# Altium schematic sheet 5.04.51805A02_RKWIFI_BT.SchDoc(5.04.51805A02_RKWIFI_BT)
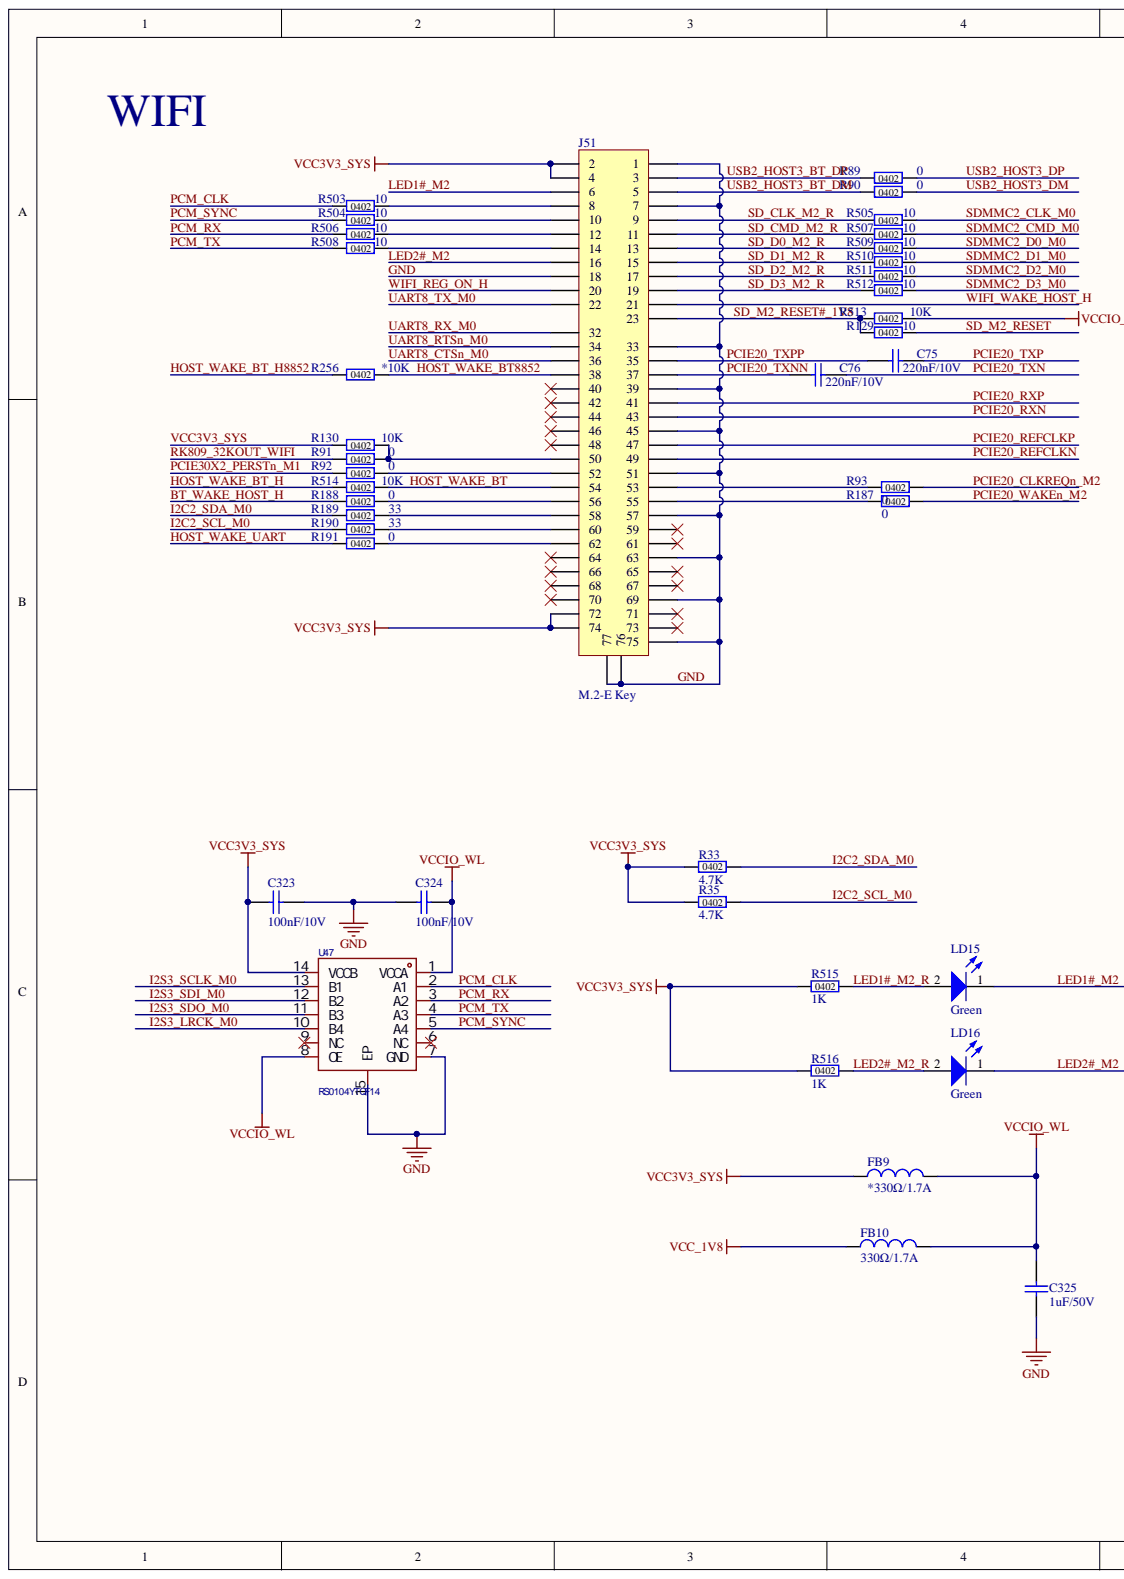
[diagram p0: 5.04.51805A02_RKWIFI_BT.SchDoc, left]
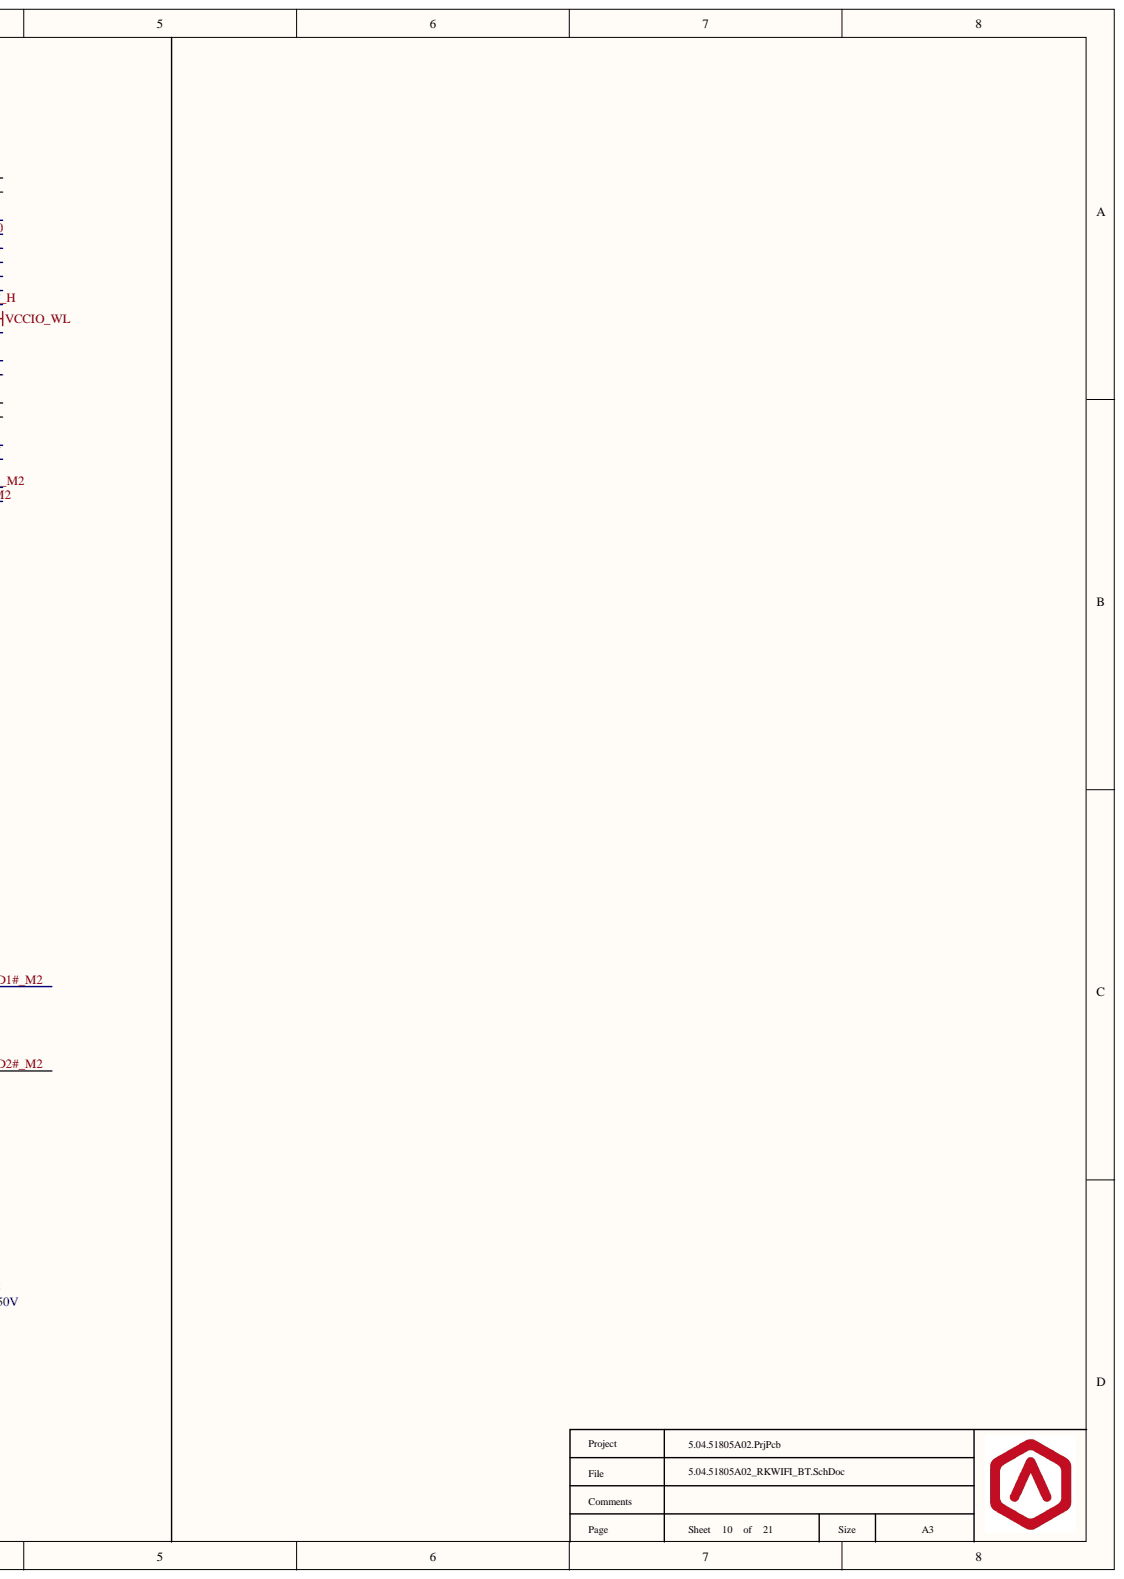
[diagram p1: 5.04.51805A02_RKWIFI_BT.SchDoc, right]

## Parts
C75  220nF/10V  [C0402]
C76  220nF/10V  [C0402]
C323  100nF/10V  [C0402]
C324  100nF/10V  [C0402]
C325  1uF/50V  [C0603]
FB9  *330Ω/1.7A  [L0603]
FB10  330Ω/1.7A  [L0603]
J51  M.2-E Key  [CONN-SMD_APCI0108-P001A]
LD15  Green  [LED0603_2]
LD16  Green  [LED0603_2]
R33  4.7K  [R0402]
R35  4.7K  [R0402]
R89  0  [R0402]
R90  0  [R0402]
R91  0  [R0402]
R92  0  [R0402]
R93  0  [R0402]
R129  10  [R0402]
R130  10K  [R0402]
R187  0  [R0402]
R188  0  [R0402]
R189  33  [R0402]
R190  33  [R0402]
R191  0  [R0402]
R256  *10K  [R0402]
R503  10  [R0402]
R504  10  [R0402]
R505  10  [R0402]
R506  10  [R0402]
R507  10  [R0402]
R508  10  [R0402]
R509  10  [R0402]
R510  10  [R0402]
R511  10  [R0402]
R512  10  [R0402]
R513  10K  [R0402]
R514  10K  [R0402]
R515  1K  [R0402]
R516  1K  [R0402]
U47  RS0104YTQF14  [VQFN-14_L3.5-W3.5-P0.50-BL-EP2.0]

## Nets
VCC_1V8: FB10.1
VCCIO_WL: C324.2 C325.2 FB9.2 FB10.2 R513.2 U47.1(VCCA) U47.8(OE)
BT_WAKE_HOST_H: R188.1
GND: C323.2 C324.1 C325.1 J51.1 J51.7 J51.18 J51.33 J51.39 J51.45 J51.51 J51.57 J51.63 J51.69 J51.75 J51.76 J51.77 U47.7(GND) U47.15(EP)
HOST_WAKE_BT: J51.54 R514.2
HOST_WAKE_BT8852: J51.38 R256.2
HOST_WAKE_BT_H: R514.1
HOST_WAKE_BT_H8852: R256.1
HOST_WAKE_UART: R191.1
I2C2_SCL_M0: R35.2 R190.1
I2C2_SDA_M0: R33.2 R189.1
I2S3_LRCK_M0: U47.10(B4)
I2S3_SCLK_M0: U47.13(B1)
I2S3_SDI_M0: U47.12(B2)
I2S3_SDO_M0: U47.11(B3)
LED1#_M2: J51.6 LD15.1
LED1#_M2_R: LD15.2 R515.2
LED2#_M2: J51.16 LD16.1
LED2#_M2_R: LD16.2 R516.2
PCIE20_CLKREQN_M2: R93.2
PCIE20_REFCLKN: J51.49
PCIE20_REFCLKP: J51.47
PCIE20_RXN: J51.43
PCIE20_RXP: J51.41
PCIE20_TXN: C76.2
PCIE20_TXNN: C76.1 J51.37
PCIE20_TXP: C75.2
PCIE20_TXPP: C75.1 J51.35
PCIE20_WAKEN_M2: R187.2
PCIE30X2_PERSTN_M1: R92.1
PCM_CLK: R503.1 U47.2(A1)
PCM_RX: R506.1 U47.3(A2)
PCM_SYNC: R504.1 U47.5(A4)
PCM_TX: R508.1 U47.4(A3)
RK809_32KOUT_WIFI: R91.1
SD_CLK_M2_R: J51.9 R505.1
SD_CMD_M2_R: J51.11 R507.1
SD_D0_M2_R: J51.13 R509.1
SD_D1_M2_R: J51.15 R510.1
SD_D2_M2_R: J51.17 R511.1
SD_D3_M2_R: J51.19 R512.1
SD_M2_RESET: R129.2
SD_M2_RESET#_1V8: J51.23 R129.1 R513.1
SDMMC2_CLK_M0: R505.2
SDMMC2_CMD_M0: R507.2
SDMMC2_D0_M0: R509.2
SDMMC2_D1_M0: R510.2
SDMMC2_D2_M0: R511.2
SDMMC2_D3_M0: R512.2
UART8_CTSN_M0: J51.36
UART8_RTSN_M0: J51.34
UART8_RX_M0: J51.32
UART8_TX_M0: J51.22
USB2_HOST3_BT_DM: J51.5 R90.1
USB2_HOST3_BT_DP: J51.3 R89.1
USB2_HOST3_DM: R90.2
USB2_HOST3_DP: R89.2
VCC3V3_SYS: C323.1 FB9.1 J51.2 J51.4 J51.72 J51.74 R33.1 R35.1 R130.1 R515.1 R516.1 U47.14(VCCB)
WIFI_REG_ON_H: J51.20
WIFI_WAKE_HOST_H: J51.21
NetJ51_8: J51.8 R503.2
NetJ51_10: J51.10 R504.2
NetJ51_12: J51.12 R506.2
NetJ51_14: J51.14 R508.2
NetJ51_50: J51.50 R91.2 R130.2
NetJ51_52: J51.52 R92.2
NetJ51_53: J51.53 R93.1
NetJ51_55: J51.55 R187.1
NetJ51_56: J51.56 R188.2
NetJ51_58: J51.58 R189.2
NetJ51_60: J51.60 R190.2
NetJ51_62: J51.62 R191.2

Not connected: J51 40 42 44 46 48 59 61 64 65 66 67 68 70 71 73; U47 6(NC) 9(NC)

## Library data of the parts
C75 C76 = C0402_220nF_10V: Ceramic Capacitor, 220nF, ±10%, 10V, -55°C~125°C, C0402; Manufacturer1: Yageo; ManufacturerPartNumber1: CC0402KRX7R6BB224; Code: 5161C224; Component Kind: Standard; Library Name: Raise3D_Capacitor.IntLib
C323 C324 = C0402_100nF_10V: Ceramic Capacitor, 100nF, ±10%, 10V, -55°C~125°C, C0402; Manufacturer1: MuRata; ManufacturerPartNumber1: GRM155R71A104KA01D; Code: 5161C104; Component Kind: Standard; Library Name: Raise3D_Capacitor.IntLib
C325 = C0603_1uF_50V: Ceramic Capacitor, 1uF, ±10%, 50V, -55°C~125°C, C0603; Manufacturer1: Yageo; ManufacturerPartNumber1: CC0603KRX7R9BB105; Code: 5192C105; Component Kind: Standard; Library Name: Raise3D_Capacitor.IntLib
FB9 FB10 = Bead_330Ohm_1.7A: Bead,MPZ1608S331A,330Ohm,1.7A,25%,L0603,-55°C~125°C; Manufacturer1: TDK; ManufacturerPartNumber1: MPZ1608S331ATA00; Code: 52010002; Component Kind: Standard; Library Name: Raise3D_Inductor&Bead.IntLib
J51 = JW_APC10108-P001A: 线对板连接器,67PINS,Pitch0.5mm,SMD,Side,0.5A,象牙白,-25°C~85°C; Manufacturer1: LX; code: 550100XX; Component Kind: Standard; ManufacturerPartNumber1: APC10108-P001A; Library Name: 5.04.51805A01_T450D核心载板.IntLib
LD15 LD16 = LED_APT1608CGCK: LED,APT1608CGCK,Green,2.5V,TOP,LED0603,-40°C~85°C; Manufacturer1: Kingbright; Code: 53010003; Component Kind: Standard; ManufacturerPartNumber1: APT1608CGCK; Library Name: Raise3D_Diode.IntLib
R33 R35 = R0402_4.7K: Resistor 4.7Kohm,5%,1/16W,R0402; Manufacturer1: Yageo; ManufacturerPartNumber1: RC0402JR-074K7L; Code: 501104K7; Component Kind: Standard; Manufacturer2: Samsung; ManufacturerPartNumber2: RCS1005J472CS; Library Name: Raise3D_Resistor.IntLib
R89-R93 R187 R188 R191 = R0402_0: Resistor 0ohm,1%,1/16W,R0402; Manufacturer1: Yageo; ManufacturerPartNumber1: RC0402FR-070RL; Code: 5001000R; Component Kind: Standard; Library Name: Raise3D_Resistor.IntLib
R129 R503-R512 = R0402_10: Resistor 10ohm,1%,1/16W,R0402; Manufacturer1: Samsung; ManufacturerPartNumber1: RC1005F100CS; Code: 5001010R; Component Kind: Standard; Library Name: Raise3D_Resistor.IntLib
R130 R256 R513 R514 = R0402_10K: Resistor 10Kohm,1%,1/16W,R0402; Manufacturer1: Yageo; ManufacturerPartNumber1: RC0402FR-0710KL; Code: 5001010K; Component Kind: Standard; Manufacturer2: Samsung; ManufacturerPartNumber2: RC1005F103CS; Library Name: Raise3D_Resistor.IntLib
R189 R190 = R0402_33: Resistor 33ohm,1%,1/16W,R0402; Manufacturer1: Yageo; ManufacturerPartNumber1: RC0402FR-0733RL; Code: 5001033R; Component Kind: Standard; Library Name: Raise3D_Resistor.IntLib
R515 R516 = R0402_1K: Resistor 1Kohm,5%,1/16W,R0402; Manufacturer1: Yageo; ManufacturerPartNumber1: RC0402JR-071KL; Code: 5011001K; Component Kind: Standard; Library Name: Raise3D_Resistor.IntLib
U47 = RS0104YTQF14: 4路电平转换,QFN-14L(3.5x3.5); Manufacturer1: RUNIC(润石); Component Kind: Standard; ManufacturerPartNumber1: RS0104YTQF14; Library Name: QQQ.IntLib
